# Altium schematic sheet DC_DC_12V.SchDoc
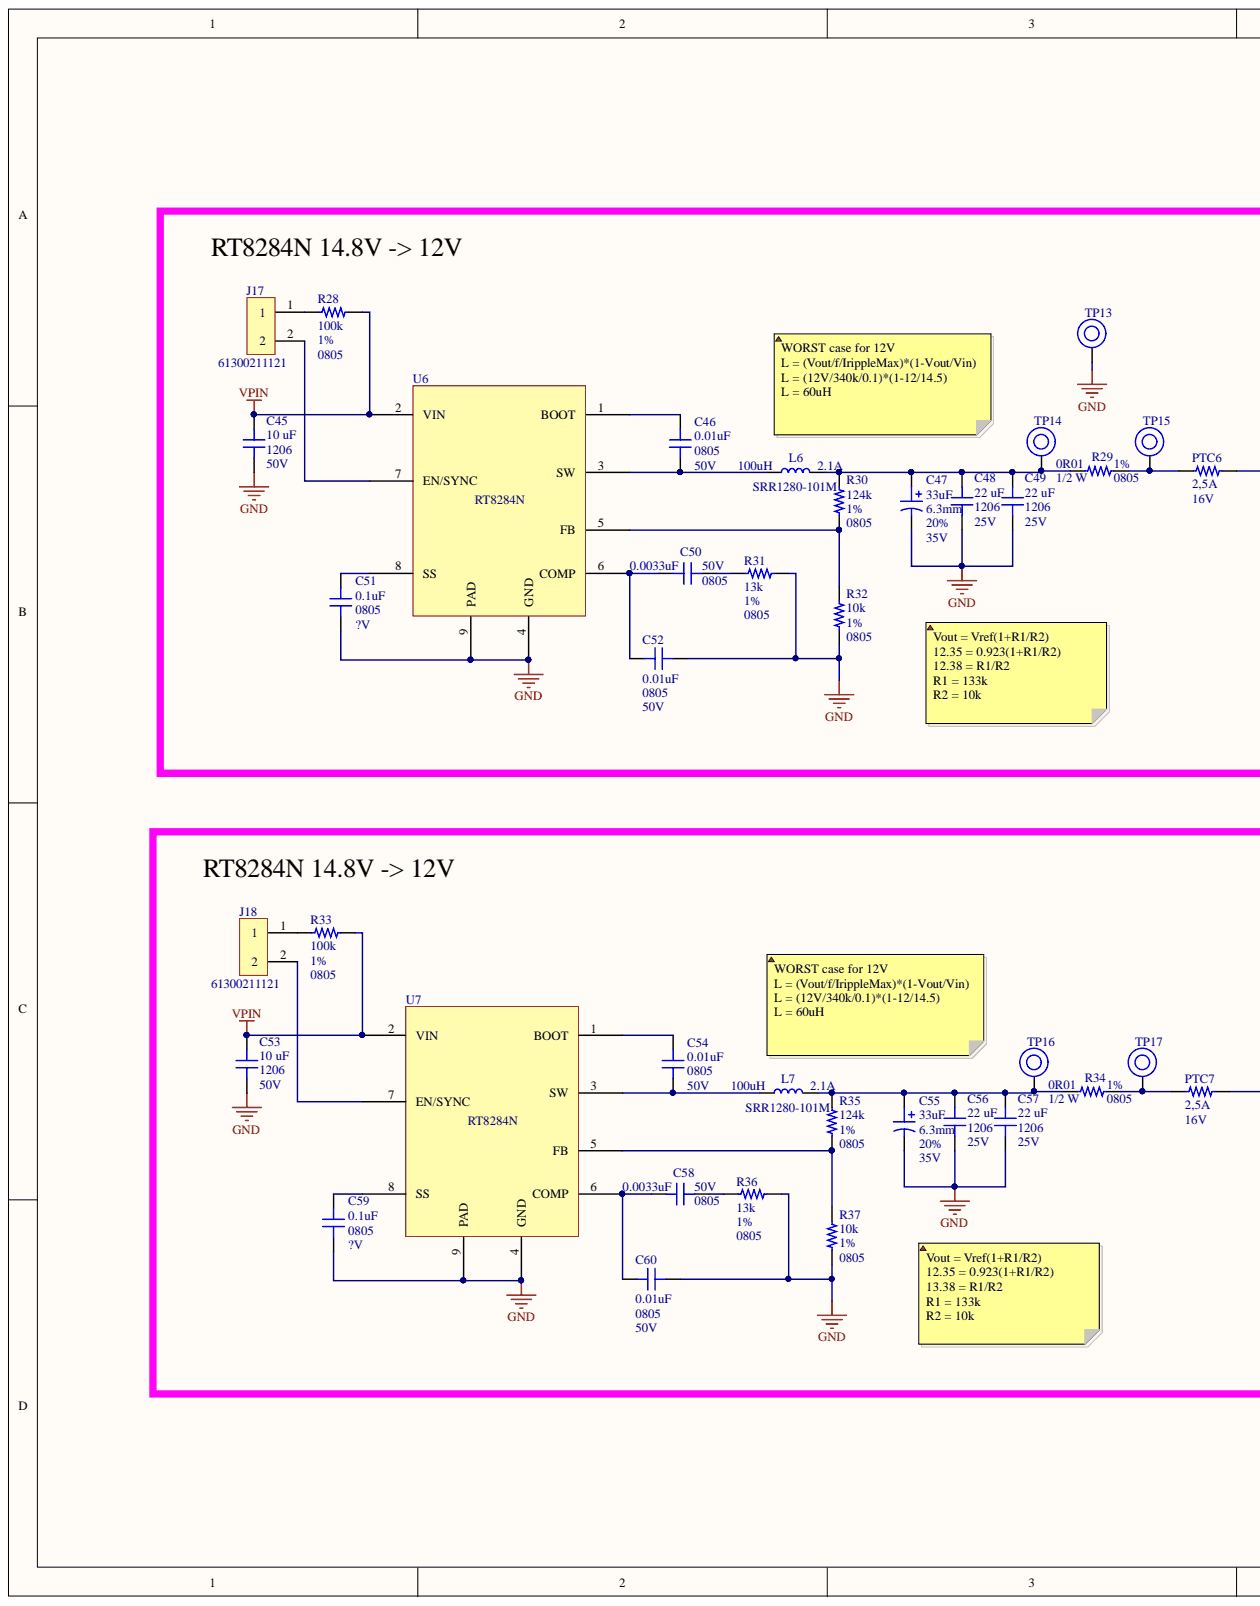
[diagram p0: DC_DC_12V.SchDoc, left]
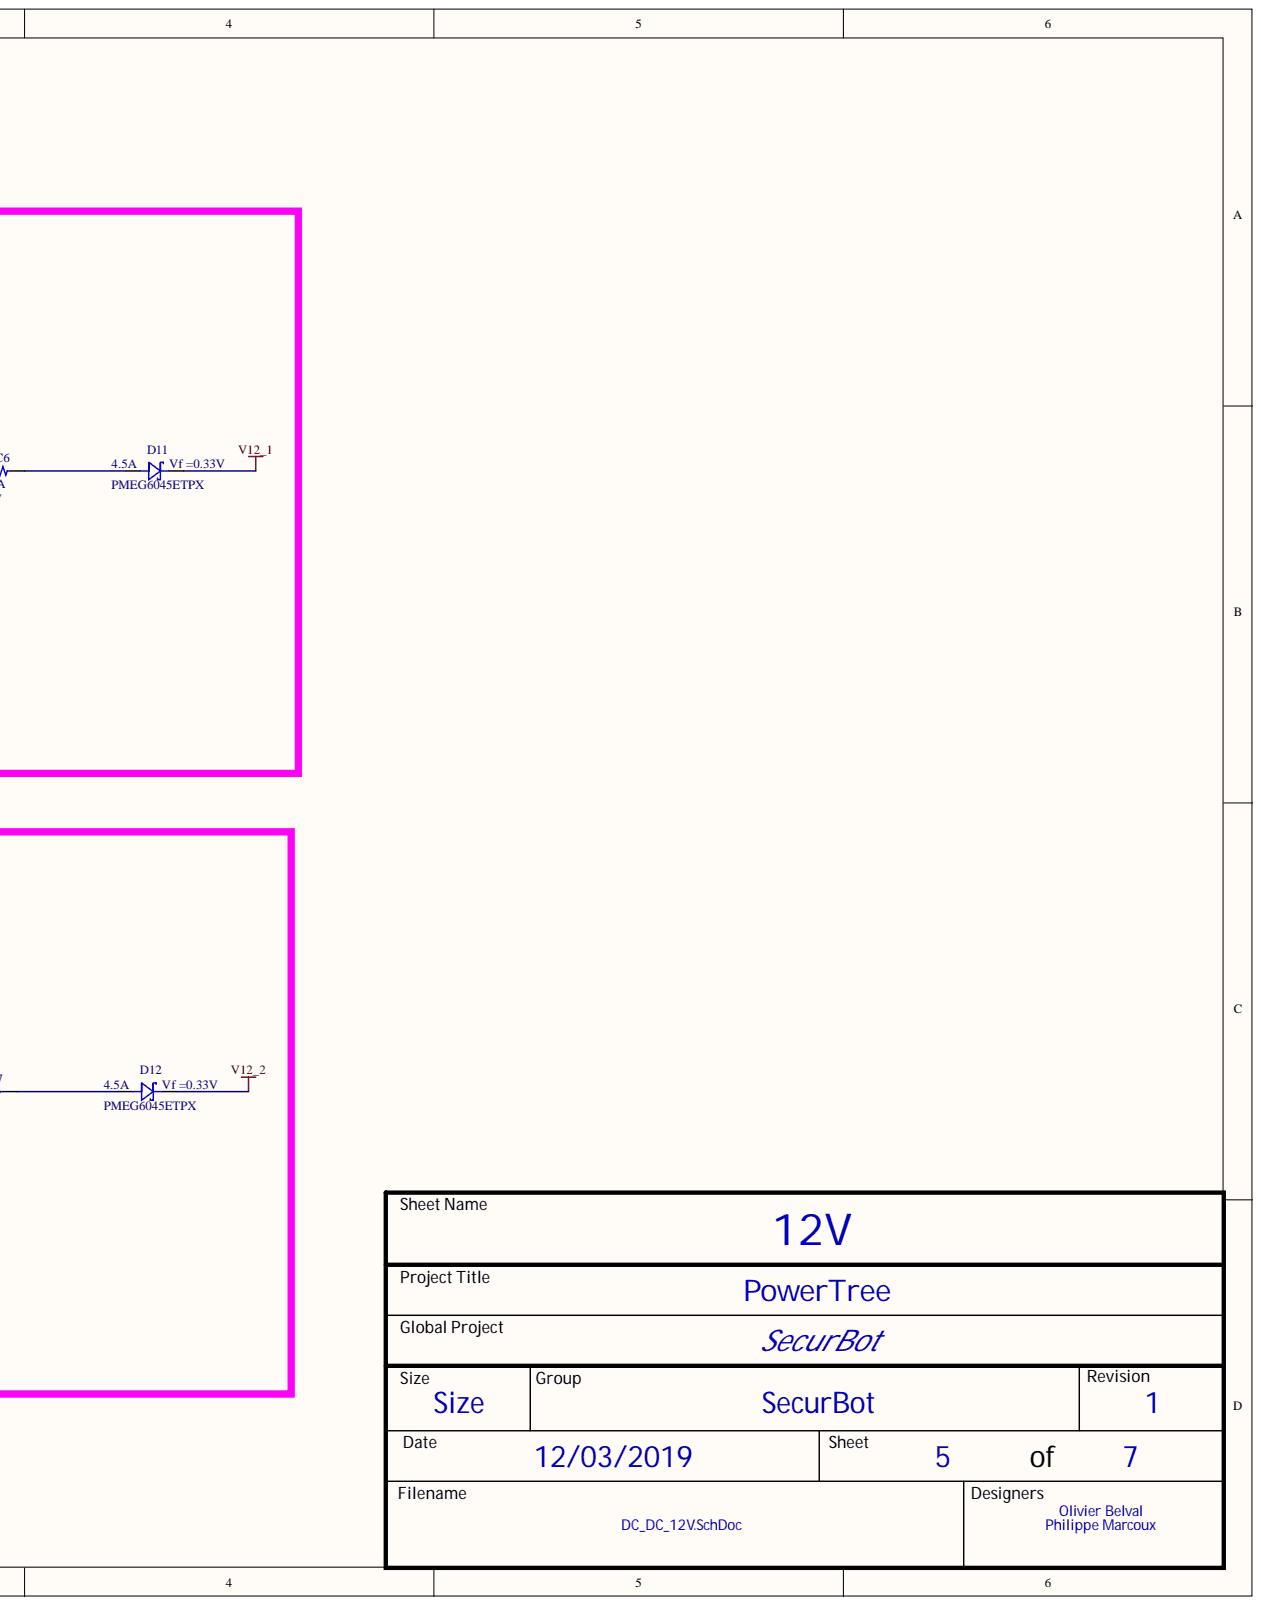
[diagram p1: DC_DC_12V.SchDoc, right]

## Parts
C45  value 10 uF  Package: 1206  Voltage: 50V  [CAP_1206]  (hidden comment: CAP - 1206)
C46  value 0.01uF  Package: 0805  Voltage: 50V  [CAP_0805]  (hidden comment: CAP - 0805)
C47  value 33uF  Package: 6.3mm  Tolerance: 20%  Voltage: 35V  [CAP ALU - 6.3mm DIA]  (hidden comment: CAP ALU - 6.3mm)
C48  value 22 uF  Package: 1206  Voltage: 25V  [CAP_1206]  (hidden comment: CAP - 1206)
C49  value 22 uF  Package: 1206  Voltage: 25V  [CAP_1206]  (hidden comment: CAP - 1206)
C50  value 0.0033uF  Package: 0805  Voltage: 50V  [CAP_0805]  (hidden comment: CAP - 0805)
C51  value 0.1uF  Package: 0805  Voltage: ?V  [CAP_0805]  (hidden comment: CAP - 0805)
C52  value 0.01uF  Package: 0805  Voltage: 50V  [CAP_0805]  (hidden comment: CAP - 0805)
C53  value 10 uF  Package: 1206  Voltage: 50V  [CAP_1206]  (hidden comment: CAP - 1206)
C54  value 0.01uF  Package: 0805  Voltage: 50V  [CAP_0805]  (hidden comment: CAP - 0805)
C55  value 33uF  Package: 6.3mm  Tolerance: 20%  Voltage: 35V  [CAP ALU - 6.3mm DIA]  (hidden comment: CAP ALU - 6.3mm)
C56  value 22 uF  Package: 1206  Voltage: 25V  [CAP_1206]  (hidden comment: CAP - 1206)
C57  value 22 uF  Package: 1206  Voltage: 25V  [CAP_1206]  (hidden comment: CAP - 1206)
C58  value 0.0033uF  Package: 0805  Voltage: 50V  [CAP_0805]  (hidden comment: CAP - 0805)
C59  value 0.1uF  Package: 0805  Voltage: ?V  [CAP_0805]  (hidden comment: CAP - 0805)
C60  value 0.01uF  Package: 0805  Voltage: 50V  [CAP_0805]  (hidden comment: CAP - 0805)
D11  Current: 4.5A  Part number: PMEG6045ETPX  Vf: Vf =0.33V  [DO-214AC_SOD128]  (hidden comment: SCHOTTKEY)
D12  Current: 4.5A  Part number: PMEG6045ETPX  Vf: Vf =0.33V  [DO-214AC_SOD128]  (hidden comment: SCHOTTKEY)
J17  Part number: 61300211121  [HDR1X2]  (hidden comment: Header 2 pin SM)
J18  Part number: 61300211121  [HDR1X2]  (hidden comment: Header 2 pin SM)
L6  value 100uH  Current: 2.1A  Part number: SRR1280-101M  [BOURNS 12.5x12.5mm]  (hidden comment: IND)
L7  value 100uH  Current: 2.1A  Part number: SRR1280-101M  [BOURNS 12.5x12.5mm]  (hidden comment: IND)
PTC6  Trip value: 2,5A  Voltage: 16V  [PTC - 1812]  (hidden comment: PTC - 1812)
PTC7  Trip value: 2,5A  Voltage: 16V  [PTC - 1812]  (hidden comment: PTC - 1812)
R28  value 100k  [FER_0805]  (hidden comment: RES - 0805)
R29  value 0R01  Package: 0805  Tolerance: 1%  Watt: 1/2 W  [FER_0805]  (hidden comment: RES - 0805)
R30  value 124k  Package: 0805  Tolerance: 1%  [FER_0805]  (hidden comment: RES - 0805)
R31  value 13k  Package: 0805  Tolerance: 1%  [FER_0805]  (hidden comment: RES - 0805)
R32  value 10k  Package: 0805  Tolerance: 1%  [FER_0805]  (hidden comment: RES - 0805)
R33  value 100k  Tolerance: 1%  [FER_0805]  (hidden comment: RES - 0805)
R34  value 0R01  Package: 0805  Tolerance: 1%  Watt: 1/2 W  [FER_0805]  (hidden comment: RES - 0805)
R35  value 124k  Package: 0805  Tolerance: 1%  [FER_0805]  (hidden comment: RES - 0805)
R36  value 13k  Package: 0805  Tolerance: 1%  [FER_0805]  (hidden comment: RES - 0805)
R37  value 10k  Package: 0805  Tolerance: 1%  [FER_0805]  (hidden comment: RES - 0805)
TP13  TestPoint 3x3mm  [TestPoint 3X3mm]  (value not drawn)
TP14  TestPoint 3x3mm  [TestPoint 3X3mm]  (value not drawn)
TP15  TestPoint 3x3mm  [TestPoint 3X3mm]  (value not drawn)
TP16  TestPoint 3x3mm  [TestPoint 3X3mm]  (value not drawn)
TP17  TestPoint 3x3mm  [TestPoint 3X3mm]  (value not drawn)
U6  RT8284N  [SOP8]
U7  RT8284N  [SOP8]

## Nets
GND: C45.2 C47.2 C48.2 C49.2 C51.2 C52.2 C53.2 C55.2 C56.2 C57.2 C59.2 C60.2 R31.2 R32.2 R36.2 R37.2 TP13.1 U6.4(GND) U6.9(PAD) U7.4(GND) U7.9(PAD)
V12_1: D11.2
V12_2: D12.2
VPIN: C45.1 C53.1 R28.2 R33.2 U6.2(VIN) U7.2(VIN)
NetC46_1: C46.1 U6.1(BOOT)
NetC46_2: C46.2 L6.1 U6.3(SW)
NetC47_1: C47.1 C48.1 C49.1 L6.2 R29.1 R30.1 TP14.1
NetC50_1: C50.1 C52.1 U6.6(COMP)
NetC50_2: C50.2 R31.1
NetC51_1: C51.1 U6.8(SS)
NetC54_1: C54.1 U7.1(BOOT)
NetC54_2: C54.2 L7.1 U7.3(SW)
NetC55_1: C55.1 C56.1 C57.1 L7.2 R34.1 R35.1 TP16.1
NetC58_1: C58.1 C60.1 U7.6(COMP)
NetC58_2: C58.2 R36.1
NetC59_1: C59.1 U7.8(SS)
NetD11_1: D11.1 PTC6.2
NetD12_1: D12.1 PTC7.2
NetJ17_1: J17.1 R28.1
NetJ17_2: J17.2 U6.7(EN/SYNC)
NetJ18_1: J18.1 R33.1
NetJ18_2: J18.2 U7.7(EN/SYNC)
NetPTC6_1: PTC6.1 R29.2 TP15.1
NetPTC7_1: PTC7.1 R34.2 TP17.1
NetR30_2: R30.2 R32.1 U6.5(FB)
NetR35_2: R35.2 R37.1 U7.5(FB)

## Library data of the parts
C45 C53 = CAP - 1206: Part number: GRT31CR61H106ME01L; Component Kind: Standard; Description: CAP - 1206; Library Name: SecureBot.SchLib
C46 C52 C54 C60 = CAP - 0805: Part number: CL21B103KBANNNC; Component Kind: Standard; Description: CAP - 0805; Library Name: SecureBot.SchLib
C47 C55 = CAP ALU - 6_3mm: Part number: EEE-FK1V330P; Component Kind: Standard; Description: CAP ALU - 6.3mm; Library Name: SecureBot.SchLib
C48 C49 C56 C57 = CAP - 1206: Part number: CL31A226KAHNNNE; Component Kind: Standard; Description: CAP - 1206; Library Name: SecureBot.SchLib
C50 C58 = CAP - 0805: Part number: CL21B332KBANNNC; Component Kind: Standard; Description: CAP - 0805; Library Name: SecureBot.SchLib
C51 C59 = CAP - 0805: Part number: CC0805KRX7R9BB104; Component Kind: Standard; Description: CAP - 0805; Library Name: SecureBot.SchLib
PTC6 PTC7 = PTC - 1812: Part number: 0ZCG0160BF2C; Component Kind: Standard; Description: PTC - 1812; Package: 1812; Res: ?; Library Name: SecureBot.SchLib
R28 = RES - 0805: Part number: RMCF0805FT100K; Tolerance: 1%; Watt: ? W; Component Kind: Standard; Description: RES - 0805; Package: 0805; Library Name: SecureBot.SchLib
R29 R34 = RES - 0805: Part number: KRL1220E-M-R010-F-T5; Component Kind: Standard; Description: RES - 0805; Library Name: SecureBot.SchLib
R30 R35 = RES - 0805: Part number: RMCF0805FT124K; Watt: ? W; Component Kind: Standard; Description: RES - 0805; Library Name: SecureBot.SchLib
R31 R36 = RES - 0805: Part number: RC0805FR-0713KL; Watt: ? W; Component Kind: Standard; Description: RES - 0805; Library Name: SecureBot.SchLib
R32 R37 = RES - 0805: Part number: RMCF0805FT10K0; Watt: ? W; Component Kind: Standard; Description: RES - 0805; Library Name: SecureBot.SchLib
R33 = RES - 0805: Part number: RMCF0805FT100K; Watt: ? W; Component Kind: Standard; Description: RES - 0805; Package: 0805; Library Name: SecureBot.SchLib
D11 D12 = SCHOTTKEY: Component Kind: Standard; Library Name: SecureBot.SchLib
J17 J18 = Header 2 pin SM: Component Kind: Standard; Library Name: SecureBot.SchLib
L6 L7 = IND: Component Kind: Standard; Description: IND; Package: ?; Library Name: SecureBot.SchLib
TP13-TP17 = TestPoint 3x3mm: Component Kind: Standard; Library Name: SecureBot.SchLib
U6 U7 = RT8284N: Component Kind: Standard; Description: RT8284N; Part number: RT8284N; Library Name: SecureBot.SchLib
